# Altium schematic sheet Sheet1.SchDoc
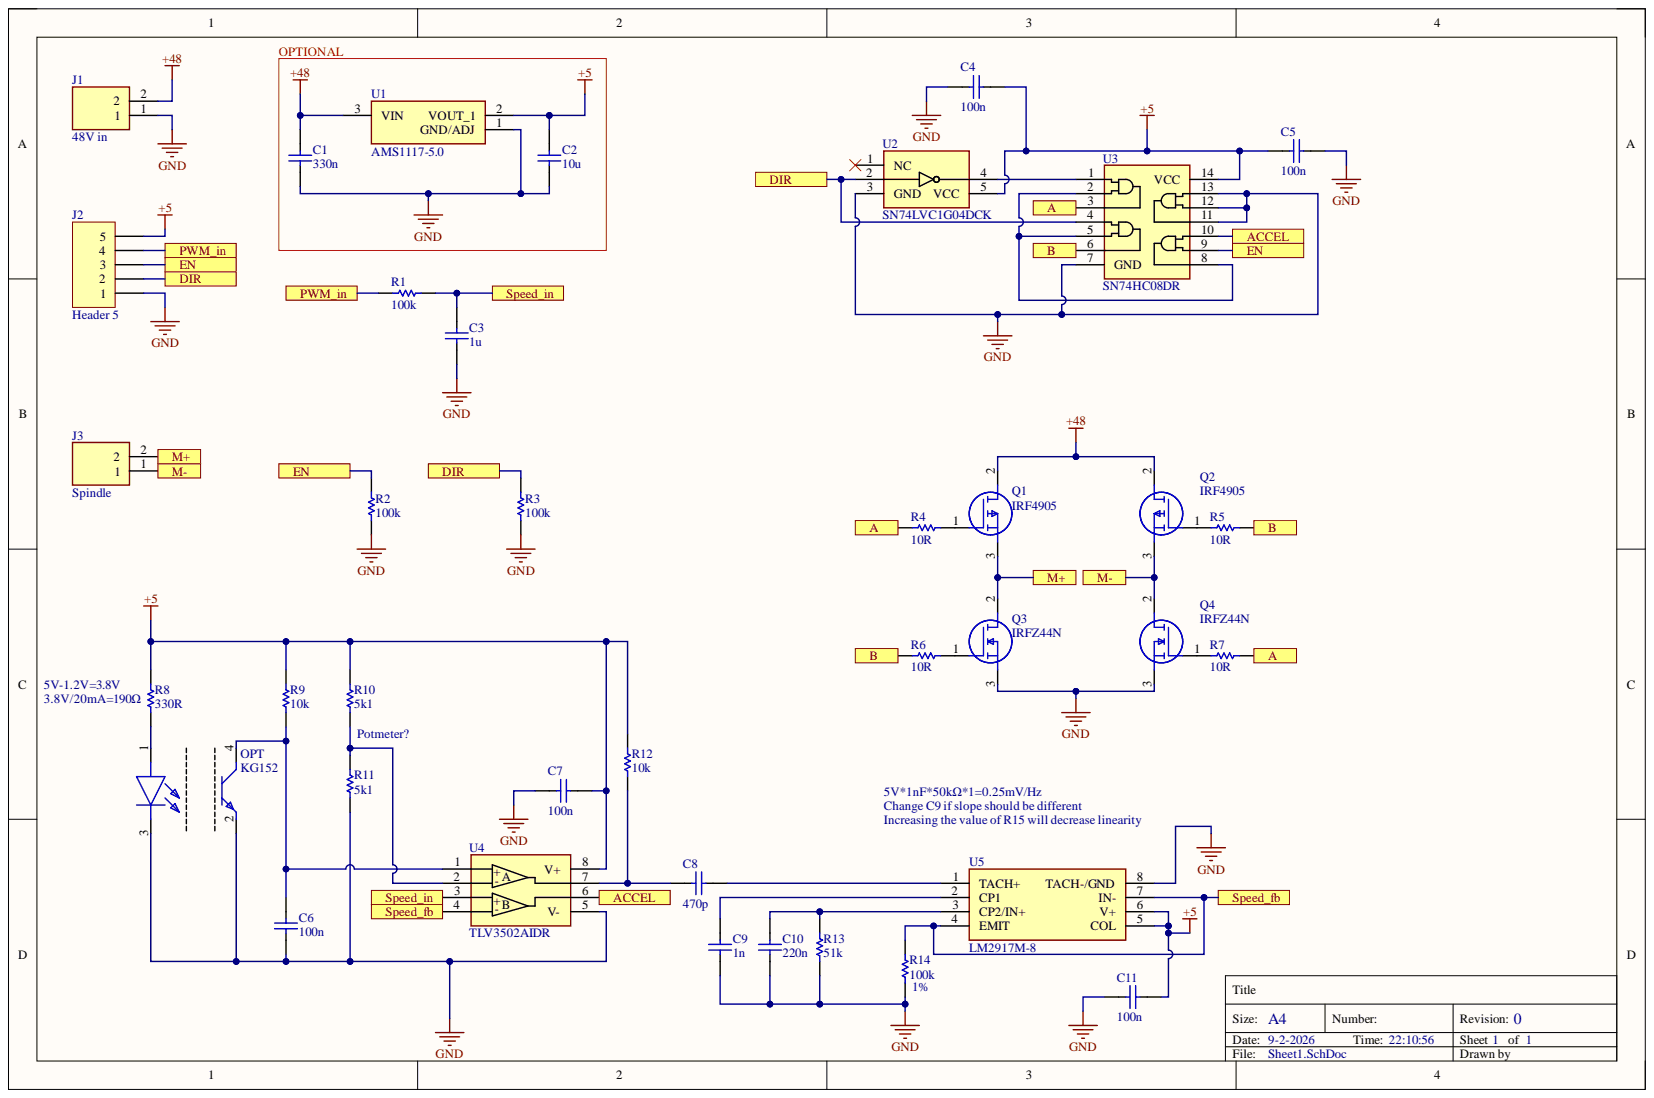
[diagram p0: Sheet1.SchDoc]

## Parts
C1  value 330n  [_General 1206]  (hidden comment: _General SMD Capacitor)
C2  value 10u  [_General 1206]  (hidden comment: _General SMD Capacitor)
C3  value 1u  [_General 1206]  (hidden comment: _General SMD Capacitor)
C4  value 100n  [_General 1206]  (hidden comment: _General SMD Capacitor)
C5  value 100n  [_General 1206]  (hidden comment: _General SMD Capacitor)
C6  value 100n  [_General 1206]  (hidden comment: _General SMD Capacitor)
C7  value 100n  [_General 1206]  (hidden comment: _General SMD Capacitor)
C8  value 470p  [_General 1206]  (hidden comment: _General SMD Capacitor)
C9  value 1n  [_General 1206]  (hidden comment: _General SMD Capacitor)
C10  value 220n  [_General 1206]  (hidden comment: _General SMD Capacitor)
C11  value 100n  [_General 1206]  (hidden comment: _General SMD Capacitor)
J1  48V in  [KF3012P]
J2  Header 5  [HDR1X5]
J3  Spindle  [KF3012P]
OPT  KG152  [GK152]
Q1  IRF4905  [TO254P469X1042X1967-3P]
Q2  IRF4905  [TO254P469X1042X1967-3P]
Q3  IRFZ44N  [TO254P440X1000X1900-3P]
Q4  IRFZ44N  [TO254P440X1000X1900-3P]
R1  value 100k  [_General 1206]  (hidden comment: _General SMD Resistor)
R2  value 100k  [_General 1206]  (hidden comment: _General SMD Resistor)
R3  value 100k  [_General 1206]  (hidden comment: _General SMD Resistor)
R4  value 10R  [_General 1206]  (hidden comment: _General SMD Resistor)
R5  value 10R  [_General 1206]  (hidden comment: _General SMD Resistor)
R6  value 10R  [_General 1206]  (hidden comment: _General SMD Resistor)
R7  value 10R  [_General 1206]  (hidden comment: _General SMD Resistor)
R8  value 330R  [_General 1206]  (hidden comment: _General SMD Resistor)
R9  value 10k  [_General 1206]  (hidden comment: _General SMD Resistor)
R10  value 5k1  [_General 1206]  (hidden comment: _General SMD Resistor)
R11  value 5k1  [_General 1206]  (hidden comment: _General SMD Resistor)
R12  value 10k  [_General 1206]  (hidden comment: _General SMD Resistor)
R13  value 51k  [_General 1206]  (hidden comment: _General SMD Resistor)
R14  value 100k  Tolerance: 1%  [_General 1206]  (hidden comment: _General SMD Resistor)
U1  AMS1117-5.0  [SOT229P700X180-4N]
U2  SN74LVC1G04DCK  [SOT65P210X110-5N]
U3  SN74HC08DR  [SOIC127P600X175-14N]
U4  TLV3502AIDR  [SOIC127P600X175-8N]
U5  LM2917M-8  [SOIC127P600X175-8N]

## Ports
A, ACCEL, B, DIR, EN, M+, M-, PWM_in, Speed_fb, Speed_in

## Nets
+5: C2.2 C4.2 C5.2 C7.2 C11.2 J2.5 R8.2 R9.2 R10.2 R12.2 U1.2 U2.5(VCC) U3.14(VCC) U4.8(V+) U5.5(COL) U5.6(V+)
+48: C1.2 J1.2 Q1.2 Q2.2 U1.3
GND: C1.1 C2.1 C3.1 C4.1 C5.1 C6.1 C7.1 C9.1 C10.1 C11.1 J1.1 J2.1 OPT.3 Q3.3 Q4.3 R2.2 R3.2 R11.1 R13.1 R14.1 U1.1 U2.3(GND) U3.7(GND) U3.11 U3.12 U3.13 U4.5(V-) U5.8(TACH-/GND)
A: R4.2 R7.1 U3.3 ; port A
ACCEL: U3.10 U4.6 ; port ACCEL
B: R5.1 R6.2 U3.6 ; port B
DIR: J2.2 R3.1 U2.2 U3.4 ; port DIR
EN: J2.3 R2.1 U3.9 ; port EN
PWM_in: J2.4 R1.2 ; port PWM_in
Speed_fb: R14.2 U4.4 U5.4(EMIT) U5.7(IN-) ; port Speed_fb
Speed_in: C3.2 R1.1 U4.3(+B) ; port Speed_in
NetC6_2: C6.2 OPT.4 R9.1 U4.1(+A)
NetC8_1: C8.1 U5.1(TACH+)
NetC8_2: C8.2 R12.1 U4.7
NetC9_2: C9.2 U5.2(CP1)
NetC10_2: C10.2 R13.2 U5.3(CP2/IN+)
NetJ3_1: J3.1 Q2.3 Q4.2
NetJ3_2: J3.2 Q1.3 Q3.2
NetOPT_1: OPT.1 R8.1
NetQ1_1: Q1.1 R4.1
NetQ2_1: Q2.1 R5.2
NetQ3_1: Q3.1 R6.1
NetQ4_1: Q4.1 R7.2
NetR10_1: R10.1 R11.2 U4.2
NetU2_4: U2.4 U3.1
NetU3_2: U3.2 U3.5 U3.8

Not connected: OPT 2; U2 1(NC)

## Library data of the parts
J1 J3 = KF301-2P: Connector; Manufacturer_Name: Handson Technology; Component Kind: Standard; Datasheet Link: http://www.handsontec.com/dataspecs/terminal%20block-5mm.pdf; Height: 10mm; Manufacturer_Part_Number: KF301-2P
J2 = Header 5: Header, 5-Pin; Component Kind: Standard; LatestRevisionDate: 17-Jul-2002; LatestRevisionNote: Re-released for DXP Platform.; Library Name: Miscellaneous Connectors.IntLib; Signal Integrity: Connector; Publisher: Altium Limited
OPT = KG152: Opto Interruptor; Component Kind: Standard; Datasheet Link: https://www.sivago.com.cn/upload/pdf/2022/GK152.pdf; Height: 12; Library Name: SamacSys.SchLib
Q1 Q2 = IRF4905: MOSFET (P-Channel); Manufacturer_Name: Infineon; Arrow Part Number: IRF4905; Arrow Price/Stock: https://www.arrow.com/en/products/irf4905/international-rectifier?region=nac; Component Kind: Standard; Datasheet Link: https://www.infineon.com/dgdl/irf4905pbf.pdf?fileId=5546d462533600a4015355e329b1197e; Height: 4.69mm; Manufacturer_Part_Number: IRF4905; Mouser Part Number: N/A; Mouser Price/Stock: https://www.mouser.co.uk/ProductDetail/Infineon-IR/IRF4905?qs=zO0nedAqnNIhlaIbSqqpvQ%3D%3D
Q3 Q4 = IRFZ44N: MOSFET (N-Channel); Manufacturer_Name: Inchange Semiconductor; Component Kind: Standard; Datasheet Link: https://4donline.ihs.com/images/VipMasterIC/IC/INSE/INSES02201/INSES02201-1.pdf?hkey=EF798316E3902B6ED9A73243A3159BB0; Height: 4.4mm; Library Name: SamacSys.SchLib; Manufacturer_Part_Number: IRFZ44N
U1 = AMS1117-5.0: Integrated Circuit; Manufacturer_Name: Advanced Monolithic Systems; Component Kind: Standard; Datasheet Link: http://www.advanced-monolithic.com/pdf/ds1117.pdf; Height: 1.8mm; Library Name: SamacSys.SchLib; Manufacturer_Part_Number: AMS1117-5.0
U2 = SN74LVC1G04DCK: Diode; Manufacturer_Name: Texas Instruments; Component Kind: Standard; Datasheet Link: https://datasheet.datasheetarchive.com/originals/distributors/Datasheets-19/DSA-377626.pdf; Height: 1.1mm; Library Name: SamacSys.SchLib; Manufacturer_Part_Number: SN74LVC1G04DCK
U3 = SN74HC08DR: Integrated Circuit; Manufacturer_Name: Texas Instruments; Arrow Part Number: SN74HC08DR; Arrow Price/Stock: https://www.arrow.com/en/products/sn74hc08dr/texas-instruments?region=nac; Component Kind: Standard; Datasheet Link: http://www.ti.com/lit/gpn/sn74hc08; Height: 1.75mm; Library Name: SamacSys.SchLib; Manufacturer_Part_Number: SN74HC08DR; Mouser Part Number: 595-SN74HC08DR; Mouser Price/Stock: https://www.mouser.co.uk/ProductDetail/Texas-Instruments/SN74HC08DR?qs=w32V8uFkMxmfv16f416C8A%3D%3D
U4 = TLV3502AIDR: Integrated Circuit; Manufacturer_Name: Texas Instruments; Arrow Part Number: TLV3502AIDR; Arrow Price/Stock: https://www.arrow.com/en/products/tlv3502aidr/texas-instruments?region=nac; Component Kind: Standard; Datasheet Link: http://www.ti.com/lit/ds/symlink/tlv3502.pdf; Height: 1.75mm; Library Name: SamacSys.SchLib; Manufacturer_Part_Number: TLV3502AIDR; Mouser Part Number: 595-TLV3502AIDR; Mouser Price/Stock: https://www.mouser.co.uk/ProductDetail/Texas-Instruments/TLV3502AIDR?qs=m96fseALk3WMRQzOszVlcA%3D%3D
U5 = LM2917M-8: Integrated Circuit; Manufacturer_Name: Texas Instruments; Arrow Part Number: LM2917M-8; Arrow Price/Stock: https://www.arrow.com/en/products/lm2917m-8/texas-instruments?utm_currency=USD&region=nac; Component Kind: Standard; Datasheet Link: https://www.ti.com/general/docs/suppproductinfo.tsp?distId=10&gotoUrl=https%3A%2F%2Fwww.ti.com%2Flit%2Fgpn%2Flm2917-n; Height: 1.75mm; Library Name: SamacSys.SchLib; Manufacturer_Part_Number: LM2917M-8; Mouser Part Number: 926-LM2917M-8; Mouser Price/Stock: https://www.mouser.co.uk/ProductDetail/Texas-Instruments/LM2917M-8?qs=X1J7HmVL2ZGMa8vib%252B3LDA%3D%3D
C1-C11 = _General SMD Capacitor: Component Kind: Standard; Library Name: SamacSys.SchLib
R1-R14 = _General SMD Resistor: Component Kind: Standard; Library Name: SamacSys.SchLib
